# Altium schematic sheet ZYNQ_PL_BANK.SchDoc
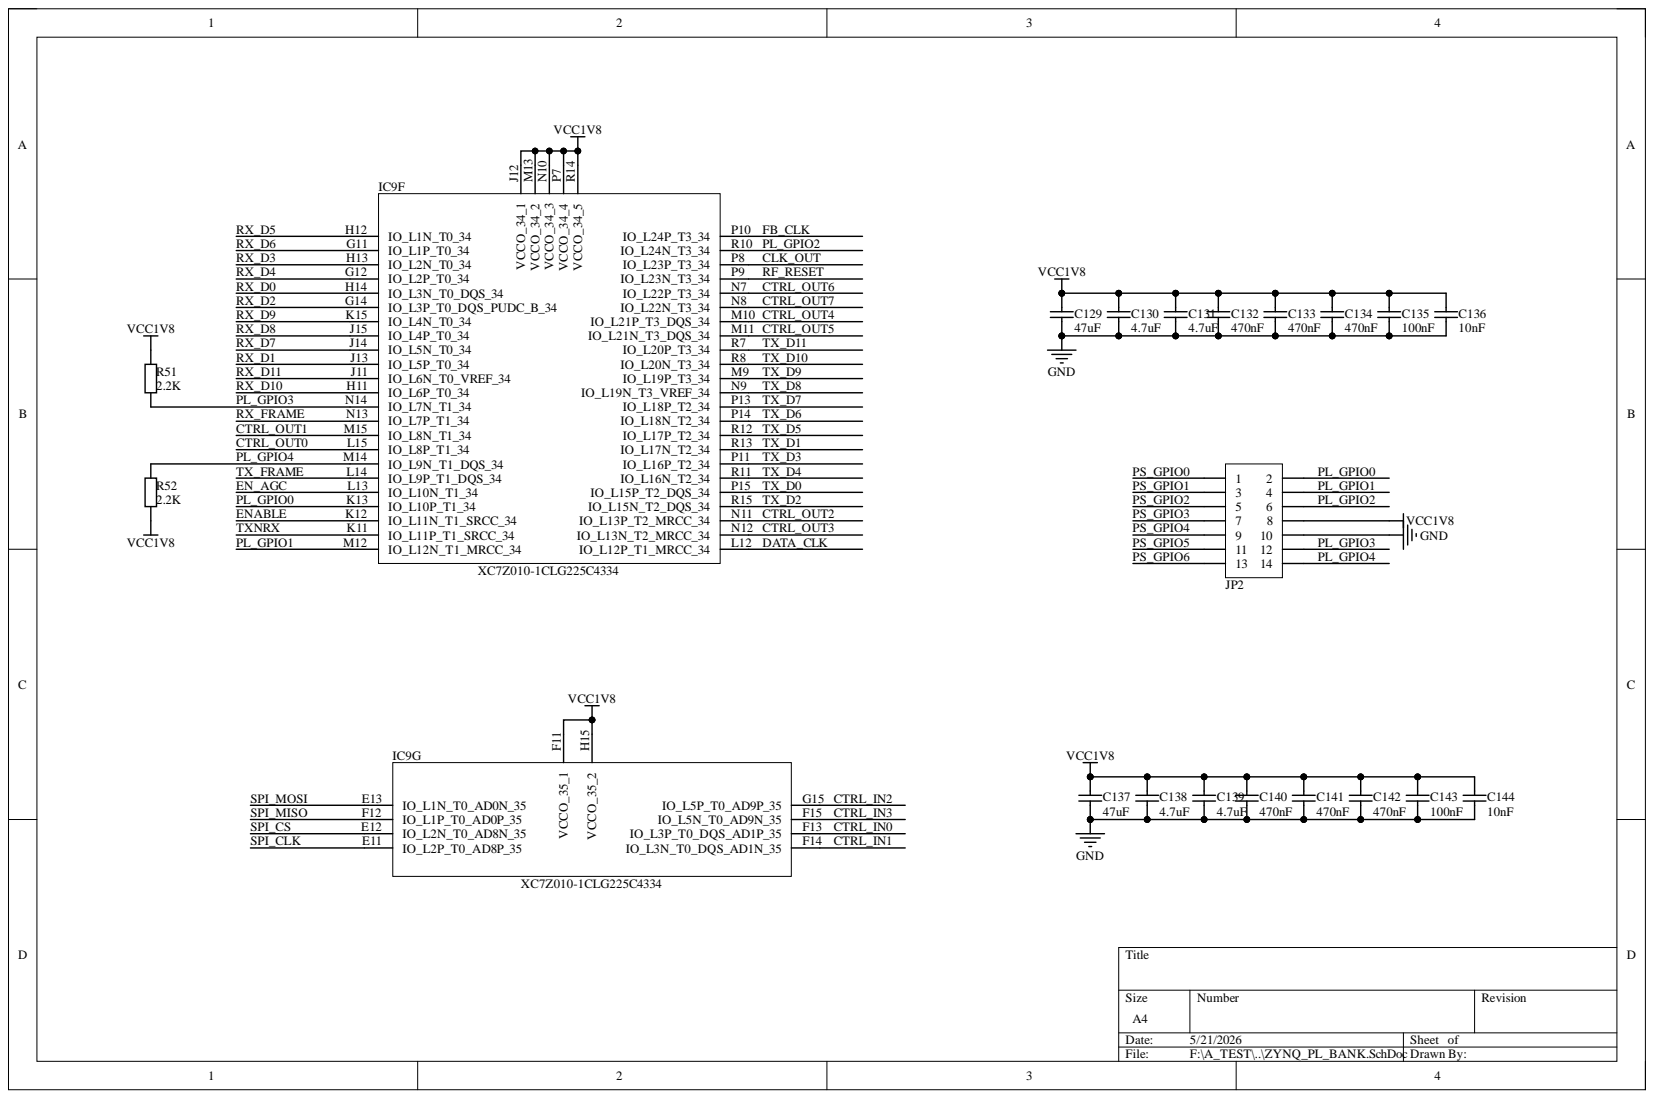
[diagram p0: ZYNQ_PL_BANK.SchDoc]

## Parts
C129  47uF  [C 0805_L]
C130  4.7uF  [C 0603_L]
C131  4.7uF  [C 0603_L]
C132  470nF  [C 0402_L]
C133  470nF  [C 0402_L]
C134  470nF  [C 0402_L]
C135  100nF  [C 0402_L]
C136  10nF  [C 0402_L]
C137  47uF  [C 0805_L]
C138  4.7uF  [C 0603_L]
C139  4.7uF  [C 0603_L]
C140  470nF  [C 0402_L]
C141  470nF  [C 0402_L]
C142  470nF  [C 0402_L]
C143  100nF  [C 0402_L]
C144  10nF  [C 0402_L]
IC9  XC7Z010-1CLG225C4334  [BGA225C80P15X15_1300X1300X150]  (parts F,G)
JP2  Header 2X7  [HDR2.54-LI-2x7P]  (value not drawn)
R51  2.2K  [R 0402_L]
R52  2.2K  [R 0402_L]

## Nets
GND: C129.1 C130.1 C131.1 C132.1 C133.1 C134.1 C135.1 C136.1 C137.1 C138.1 C139.1 C140.1 C141.1 C142.1 C143.1 C144.1 JP2.10
VCC1V8: C129.2 C130.2 C131.2 C132.2 C133.2 C134.2 C135.2 C136.2 C137.2 C138.2 C139.2 C140.2 C141.2 C142.2 C143.2 C144.2 IC9.F11(VCCO_35_1) IC9.H15(VCCO_35_2) IC9.J12(VCCO_34_1) IC9.M13(VCCO_34_2) IC9.N10(VCCO_34_3) IC9.P7(VCCO_34_4) IC9.R14(VCCO_34_5) JP2.8 R51.2 R52.1
CLK_OUT: IC9.P8(IO_L23P_T3_34)
CTRL_IN0: IC9.F13(IO_L3P_T0_DQS_AD1P_35)
CTRL_IN1: IC9.F14(IO_L3N_T0_DQS_AD1N_35)
CTRL_IN2: IC9.G15(IO_L5P_T0_AD9P_35)
CTRL_IN3: IC9.F15(IO_L5N_T0_AD9N_35)
CTRL_OUT0: IC9.L15(IO_L8P_T1_34)
CTRL_OUT1: IC9.M15(IO_L8N_T1_34)
CTRL_OUT2: IC9.N11(IO_L13P_T2_MRCC_34)
CTRL_OUT3: IC9.N12(IO_L13N_T2_MRCC_34)
CTRL_OUT4: IC9.M10(IO_L21P_T3_DQS_34)
CTRL_OUT5: IC9.M11(IO_L21N_T3_DQS_34)
CTRL_OUT6: IC9.N7(IO_L22P_T3_34)
CTRL_OUT7: IC9.N8(IO_L22N_T3_34)
DATA_CLK: IC9.L12(IO_L12P_T1_MRCC_34)
EN_AGC: IC9.L13(IO_L10N_T1_34)
ENABLE: IC9.K12(IO_L11N_T1_SRCC_34)
FB_CLK: IC9.P10(IO_L24P_T3_34)
PL_GPIO0: IC9.K13(IO_L10P_T1_34) JP2.2
PL_GPIO1: IC9.M12(IO_L12N_T1_MRCC_34) JP2.4
PL_GPIO2: IC9.R10(IO_L24N_T3_34) JP2.6
PL_GPIO3: IC9.N14(IO_L7N_T1_34) JP2.12 R51.1
PL_GPIO4: IC9.M14(IO_L9N_T1_DQS_34) JP2.14 R52.2
PS_GPIO0: JP2.1
PS_GPIO1: JP2.3
PS_GPIO2: JP2.5
PS_GPIO3: JP2.7
PS_GPIO4: JP2.9
PS_GPIO5: JP2.11
PS_GPIO6: JP2.13
RF_RESET: IC9.P9(IO_L23N_T3_34)
RX_D0: IC9.H14(IO_L3N_T0_DQS_34)
RX_D1: IC9.J13(IO_L5P_T0_34)
RX_D2: IC9.G14(IO_L3P_T0_DQS_PUDC_B_34)
RX_D3: IC9.H13(IO_L2N_T0_34)
RX_D4: IC9.G12(IO_L2P_T0_34)
RX_D5: IC9.H12(IO_L1N_T0_34)
RX_D6: IC9.G11(IO_L1P_T0_34)
RX_D7: IC9.J14(IO_L5N_T0_34)
RX_D8: IC9.J15(IO_L4P_T0_34)
RX_D9: IC9.K15(IO_L4N_T0_34)
RX_D10: IC9.H11(IO_L6P_T0_34)
RX_D11: IC9.J11(IO_L6N_T0_VREF_34)
RX_FRAME: IC9.N13(IO_L7P_T1_34)
SPI_CLK: IC9.E11(IO_L2P_T0_AD8P_35)
SPI_CS: IC9.E12(IO_L2N_T0_AD8N_35)
SPI_MISO: IC9.F12(IO_L1P_T0_AD0P_35)
SPI_MOSI: IC9.E13(IO_L1N_T0_AD0N_35)
TX_D0: IC9.P15(IO_L15P_T2_DQS_34)
TX_D1: IC9.R13(IO_L17N_T2_34)
TX_D2: IC9.R15(IO_L15N_T2_DQS_34)
TX_D3: IC9.P11(IO_L16P_T2_34)
TX_D4: IC9.R11(IO_L16N_T2_34)
TX_D5: IC9.R12(IO_L17P_T2_34)
TX_D6: IC9.P14(IO_L18N_T2_34)
TX_D7: IC9.P13(IO_L18P_T2_34)
TX_D8: IC9.N9(IO_L19N_T3_VREF_34)
TX_D9: IC9.M9(IO_L19P_T3_34)
TX_D10: IC9.R8(IO_L20N_T3_34)
TX_D11: IC9.R7(IO_L20P_T3_34)
TX_FRAME: IC9.L14(IO_L9P_T1_DQS_34)
TXNRX: IC9.K11(IO_L11P_T1_SRCC_34)

## Library data of the parts
C129-C144 = Cap: 贴片电容; Component Kind: Standard; Library Name: A_Schlib.SchLib
  C129 C137: value: 47uF
  C130 C131 C138 C139: value: 4.7uF
  C132-C134 C140-C142: value: 470nF
  C135 C143: value: 100nF
  C136 C144: value: 10nF
IC9 = XC7Z010-1CLG225C4334: Integrated Circuit; Manufacturer_Name: AMD; Component Kind: Standard; Datasheet Link: https://docs.amd.com/api/khub/documents/kOAtMqkVamwUDaIfCXO91A/content?Ft-Calling-App=ft%2Fturnkey-portal&Ft-Calling-App-Version=5.2.5#; Height: 1.5mm; Library Name: SamacSys.SchLib; Manufacturer_Part_Number: XC7Z010-1CLG225C4334
JP2 = Header 7X2: 7*2P接插件; 版权归属: 封装库来自淘宝 ID：黄晶宝石; BOM名称: 7*2P接插件; Component Kind: Standard; 学习资源: https://shop463601444.taobao.com; Library Name: 2.54间距排针排母插件贴片.SchLib
R51 R52 = Res: Component Kind: Standard; value: 2.2K; Library Name: 零散元件库2.SCHLIB
